# Altium schematic sheet Sheet_PSU.SchDoc
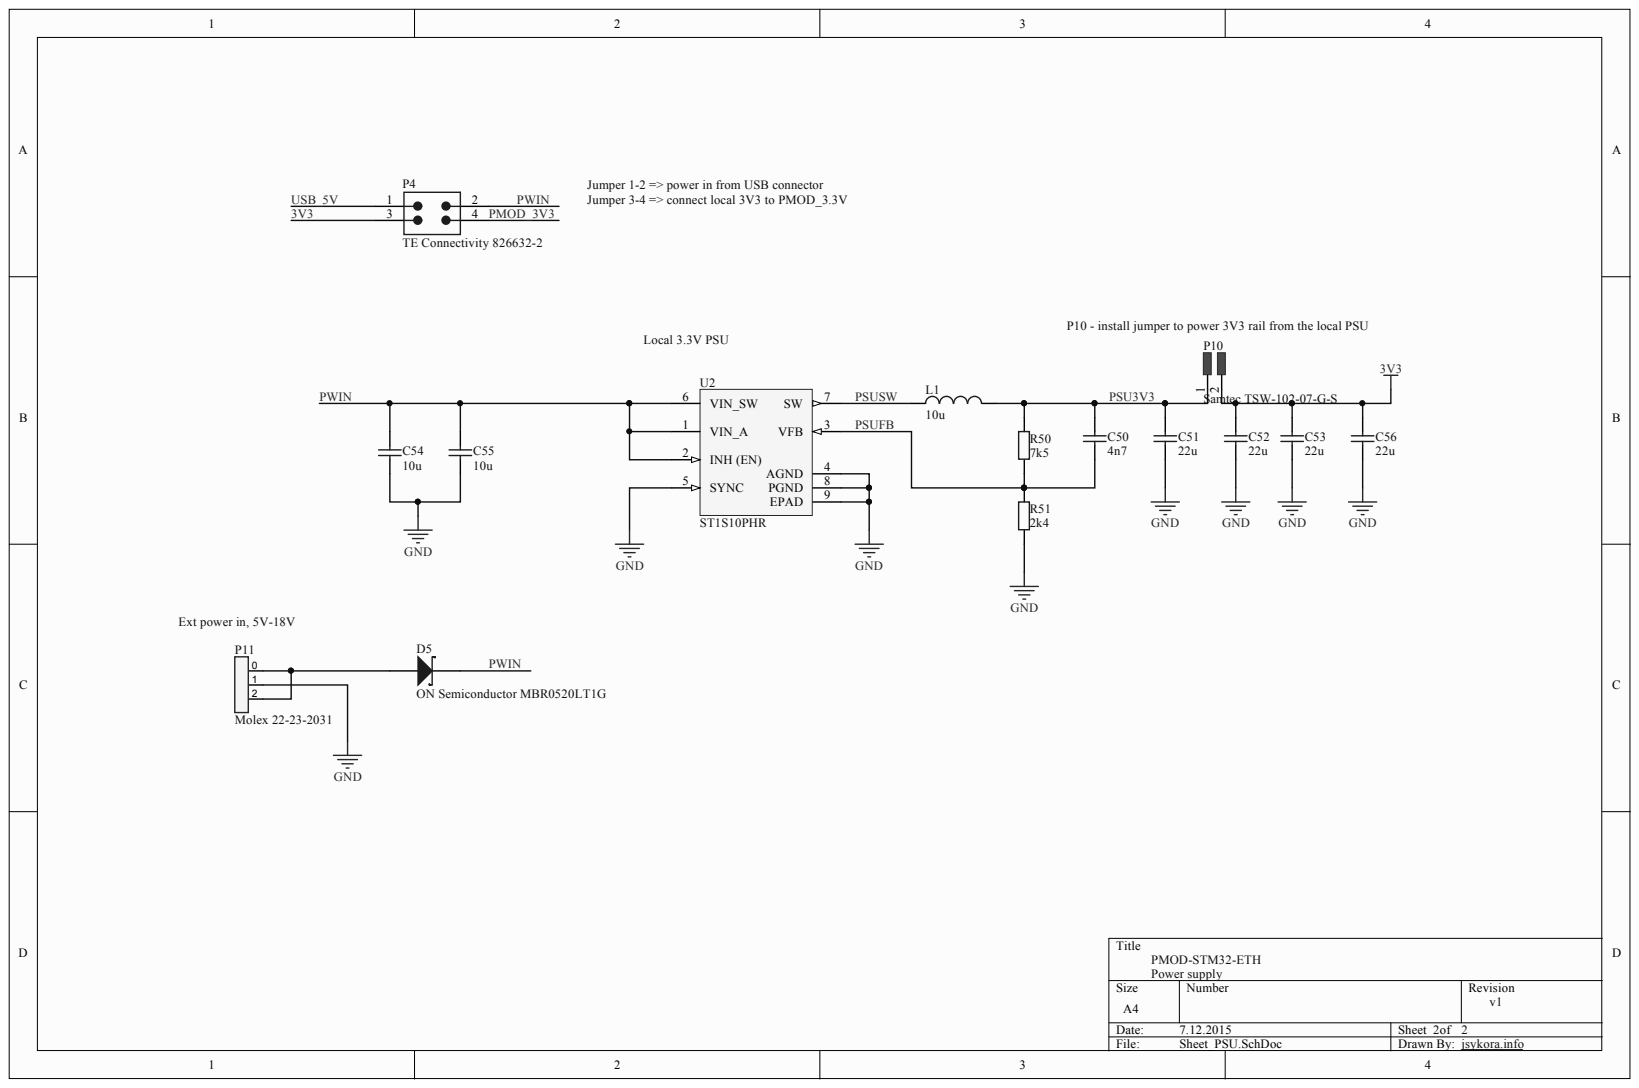
[diagram p0: Sheet_PSU.SchDoc]

## Parts
C50  4n7  [CAPC1608X90X35ML10T15]
C51  22u  [CAPC2013X140X45ML15T25]
C52  22u  [CAPC2013X140X45ML15T25]
C53  22u  [CAPC2013X140X45ML15T25]
C54  10u  [CAPC3216X180X55ML20T25]
C55  10u  [CAPC3216X180X55ML20T25]
C56  22u  [CAPC2013X140X45ML15T25]
D5  ON Semiconductor MBR0520LT1G  [SOD-123_B]
L1  10u  [PCB-4393626-1]
P4  TE Connectivity 826632-2  [TE-826632_V]
P10  Samtec TSW-102-07-G-S  [PCB-660195-1]
P11  Molex 22-23-2031  [PCB-642408-1]
R50  7k5  [RESC1609X50X30ML10T20]
R51  2k4  [RESC1609X50X30ML10T20]
U2  ST1S10PHR  [HSO-8_N]

## Nets
GND: C51.1 C52.1 C53.1 C54.1 C55.1 C56.1 P11.1 R51.1 U2.4(AGND) U2.5(SYNC) U2.8(PGND) U2.9(EPAD)
3V3: C52.2 C53.2 C56.2 P4.3 P10.2
PMOD_3V3: P4.4
PSU3V3: C50.2 C51.2 L1.2 P10.1 R50.2
PSUFB: C50.1 R50.1 R51.2 U2.3(VFB)
PSUSW: L1.1 U2.7(SW)
PWIN: C54.2 C55.2 D5.1 P4.2 U2.1(VIN_A) U2.2(INH_(EN)) U2.6(VIN_SW)
USB_5V: P4.1
NetD5_2: D5.2 P11.0 P11.2

## Library data of the parts
C50 = CMP-132446-3: CAP CER 4700PF 50V 10% X7R 0603; Capacitance: 4.7e-09 F; Case/Package (SI): 1608; Lead-Free Status: Lead Free; Voltage Rating (DC): 50.0 V; Capacitance Tolerance: ±10%; Component Kind: Standard; Dielectric Characteristic: X7R; Library Name: CommunityVault; Lifecycle Status: Active; OctopartID: 609da9275c4cbf5a; Octopart Url: https://octopart.com/grm188r71h472ka01j-murata-11540919; RoHS: Compliant; Size-Length: 0.0016 m; Size-Thickness: 0.0008 m; Size-Width: 0.0008 m
C51-C53 C56 = CMP-19299736-2: CAP CER 22UF 6.3V 20% X5R 0805; Capacitance: 2.2e-05 F; Case/Package (SI): 2012; Dielectric Material: Ceramic Multilayer; Lead-Free Status: Lead Free; Capacitance Tolerance: ±20%; Component Kind: Standard; Dielectric Characteristic: X5R; Library Name: CommunityVault; Lifecycle Status: Active; Mounting Style: Surface Mount; Number of Pins: 2; OctopartID: be8f778764b96148; Octopart Url: https://octopart.com/grm21br60j226me39l-murata-196432; REACH SVHC Compliance: No SVHC; RoHS: Compliant; Size-Height: 0.00125 m; Size-Length: 0.002 m; Size-Thickness: 0.00125 m; Size-Width: 0.00125 m; Voltage Rating (DC): 6.3 V
C54 C55 = CMP-142389-2: Cap Ceramic 10uF 25V X7R 10% SMD 1206 125C Embossed T/R; Capacitance: 1e-05 F; Case/Package (SI): 3216; Dielectric Material: Ceramic Multilayer; Lead-Free Status: Lead Free; Voltage Rating (DC): 25.0 V; Capacitance Tolerance: ±10%; Component Kind: Standard; Dielectric Characteristic: X7R; Library Name: CommunityVault; Lifecycle Status: Active; Mounting Style: Surface Mount; Number of Pins: 2; OctopartID: 5e4197c194b58186; Octopart Url: https://octopart.com/grm31cr71e106ka12l-murata-9105298; Packaging: Cut Tape (CT); REACH SVHC Compliance: No SVHC; RoHS: Compliant; Size-Length: 0.0032 m; Size-Thickness: 0.0016 m; Size-Width: 0.0016 m
D5 = CMP-3786467-8: Rectifier, Schottky Power; Plastic Sod-123; 0.5 a @ +90c If; +125; 20v; 1000; Current Rating: 0.5 A; Forward Voltage: 0.385 V; Lead-Free Status: Lead Free; Polarity: Standard; Voltage Rating (DC): 20.0 V; Case/Package: SOD-123; Component Kind: Standard; Library Name: CommunityVault; Lifecycle Status: Active; Manufacturer Part Number: MBR0520LT1G; Mounting Style: Surface Mount; Number of Pins: 2; OctopartID: 81934899af805e54; Octopart Url: http://octopart.com/mbr0520lt1g-on+semiconductor-2933; REACH SVHC Compliance: No SVHC; RoHS: Compliant; Seller Landing  Url: https://octopart-clicks.com/click/track?country=US&ak=8157f166&sig=02b8313&sid=5489&ppid=2933&vpid=120602749&ct=offers
L1 = CDRH74NP-221MC-B: POWER INDUCTOR 220UH 0.36A SMD; Lead-Free Status: Lead Free; Component Kind: Standard; Library Name: Octopart library; OctopartID: 273cef4f55c3f8fb; Octopart Url: https://octopart.com/cdrh74np-221mc-b-sumida-24729497; RoHS: Compliant
P4 = CMP-664068-5: Headers & Wire Housings 2X02P DUAL ROW; Current Rating: 3.0 A; ELV Compliant: Compliant; Gender: Male; Number of Contacts: 4; Pin Pitch: 0.00254 m; Component Kind: Standard; Contact Material: Phosphor Bronze; Flammability Rating: UL 94 V-0; Housing Color: Green; Housing Material: Thermoplastic; Library Name: CommunityVault; Lifecycle Status: Active; Mounting Style: PCB; OctopartID: 8a1c4193adca55db; Octopart Url: https://octopart.com/826632-2-te+connectivity-42271408; Orientation: Vertical; RoHS: Compliant
P10 = TSW-102-07-G-S: CONN HEADER 2POS .100" SGL GOLD; Gender: Male; Lead-Free Status: Lead Free; Number of Contacts: 2; Pin Pitch: 0.00254 m; Component Kind: Standard; Contact Material: Phosphor Bronze; Contact Plating: Gold; Housing Color: Black; Housing Material: Plastic; Library Name: Octopart library; Mounting Style: Through Hole; Number of Positions: 2; Number of Rows: 1; OctopartID: 31c1f8cf33e2ee06; Octopart Url: https://octopart.com/tsw-102-07-g-s-samtec-272197; REACH SVHC Compliance: No SVHC; RoHS: Compliant; Size-Height: 0.00254 m; Size-Length: 0.00508 m; Size-Width: 0.00254 m
P11 = CMP-642408-7: Headers & Wire Housings VERT PCB HDR 3P TIN FRICTION LOCK; Current Rating: 4.0 A; Gender: Male; Glow Wire Compliant: Non-Compliant; Insulation Resistance: 5e+11 Ω; Lead-Free Status: Lead Free; Number of Circuits: 3; Pin Pitch: 0.00254 m; Voltage Rating (DC): 250.0 V; Color: Off-White; Component Kind: Standard; Contact Material: Brass; Contact Plating: Tin; Flammability Rating: UL 94 V-0; Halogen Free Status: Low Halogen; Housing Color: White; Housing Material: Nylon; Library Name: CommunityVault; Lifecycle Status: Active; Number of Contacts: 3; Number of Positions: 3; Number of Rows: 1; OctopartID: 80fc4ad762e85f3b; Octopart Url: https://octopart.com/22-23-2031-molex-2587; Orientation: Vertical; Packaging: Bulk; RoHS: Compliant; Size-Height: 0.00818 m; Size-Length: 0.00762 m; Size-Width: 0.00635 m
R50 = CMP-1857240-2: RES SMD 7.5K OHM 1% 1/10W 0603; Lead-Free Status: Lead Free; Power Rating: 0.1 W; Resistance: 7500.0 Ω; Temperature Coefficient: 100.0 ppm/°C; Voltage Rating (DC): 75.0 V; Case/Package: 0603; Component Kind: Standard; Library Name: CommunityVault; Mounting Style: Surface Mount; Number of Pins: 2; OctopartID: dcfe17ab14c50339; Octopart Url: https://octopart.com/crcw06037k50fkea-vishay-39841117; REACH SVHC Compliance: No SVHC; Resistance Tolerance: ±1%; RoHS: Compliant; Size-Height: 0.00045 m; Size-Length: 0.00155 m; Size-Width: 0.00085 m
R51 = CMP-1857295-2: RES SMD 2.49K OHM 1% 1/10W 0603; Lead-Free Status: Lead Free; Power Rating: 0.1 W; Resistance: 2490.0 Ω; Temperature Coefficient: 100.0 ppm/°C; Voltage Rating (DC): 75.0 V; Case/Package: 0603; Component Kind: Standard; Library Name: CommunityVault; Mounting Style: Surface Mount; Number of Pins: 2; OctopartID: 98345361145047f3; Octopart Url: https://octopart.com/crcw06032k49fkea-vishay-39823618; REACH SVHC Compliance: No SVHC; Resistance Tolerance: ±1%; RoHS: Compliant; Size-Height: 0.00045 m; Size-Length: 0.00155 m; Size-Width: 0.00085 m
U2 = ST1S10PHR: Monolithic synchronous step-down regulator, 8-Pin HSOP, Tape and Reel; Lead-Free Status: Lead Free; Number of Outputs: 1; Output Current: 3.0 A; Component Kind: Standard; Library Name: Octopart library; Lifecycle Status: Active; Number of Pins: 8; OctopartID: 3562fcc938a8eaa5; Octopart Url: https://octopart.com/st1s10phr-stmicroelectronics-10561708; REACH SVHC Compliance: No SVHC; RoHS: Compliant
